# Altium schematic sheet FTDI.SchDoc(FTDI)
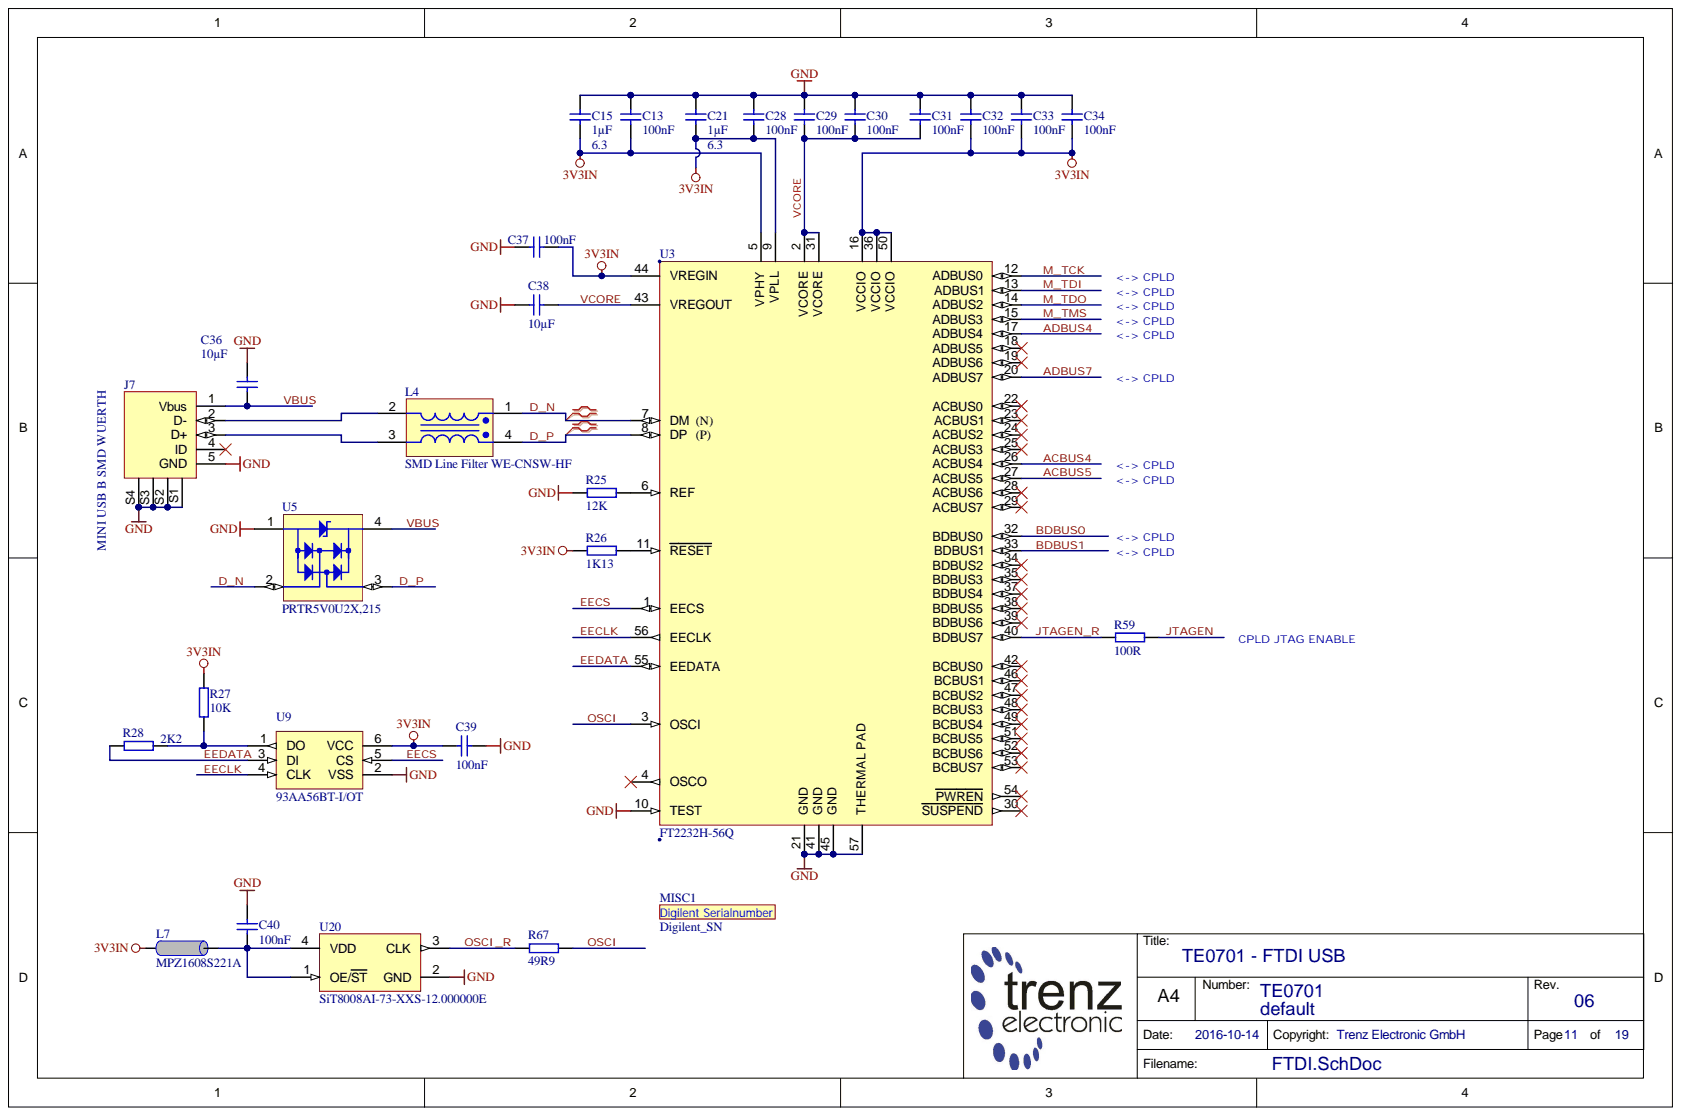
[diagram p0: FTDI.SchDoc]

## Parts
C13  100nF  [CAPC1005X55N]
C15  1µF  Voltage: 6.3  [CAPC1005X55N]
C21  1µF  Voltage: 6.3  [CAPC1005X55N]
C28  100nF  [CAPC1005X55N]
C29  100nF  [CAPC1005X55N]
C30  100nF  [CAPC1005X55N]
C31  100nF  [CAPC1005X55N]
C32  100nF  [CAPC1005X55N]
C33  100nF  [CAPC1005X55N]
C34  100nF  [CAPC1005X55N]
C36  10µF  [CAPC2012X135N]
C37  100nF  [CAPC1005X55N]
C38  10µF  [CAPC2012X135N]
C39  100nF  [CAPC1005X55N]
C40  100nF  [CAPC1005X55N]
J7  MINI USB B SMD WUERTH  [MINI USB 5S B TYPE]
L4  SMD Line Filter WE-CNSW-HF  [WE-CNSW-HF]
L7  MPZ1608S221A  [INDC1608X95N]
MISC1  Digilent_SN  (mechanical)
R25  12K  [RESC1005X40N]
R26  1K13  [RESC1005X40N]
R27  10K  [RESC1005X40N]
R28  2K2  [RESC1005X40N]
R59  100R  [RESC1005X40N]
R67  49R9  [RESC1005X40N]
U3  FT2232H-56Q  [QFN50P800X800-57T610X610N]
U5  PRTR5V0U2X,215  [SOT190P229X114-4N]
U9  93AA56BT-I/OT  [SOT95P280X145-6N]
U20  SiT8008AI-73-XXS-12.000000E  Database Table Name: CLK  [2016]

## Nets
3V3IN: C13.2 C15.2 C21.2 C28.2 C32.2 C33.2 C34.2 C37.2 C39.1 L7.1 R26.1 R27.1 U3.5(VPHY) U3.9(VPLL) U3.16(VCCIO) U3.36(VCCIO) U3.44(VREGIN) U3.50(VCCIO) U9.6(VCC)
GND: C13.1 C15.1 C21.1 C28.1 C29.1 C30.1 C31.1 C32.1 C33.1 C34.1 C36.1 C37.1 C38.1 C39.2 C40.2 J7.5(GND) J7.S1 J7.S2 J7.S3 J7.S4 R25.1 U3.10(TEST) U3.21(GND) U3.41(GND) U3.45(GND) U3.57(THERMAL_PAD) U5.1 U9.2(VSS) U20.2(GND)
ACBUS4: U3.26(ACBUS4)
ACBUS5: U3.27(ACBUS5)
ADBUS4: U3.17(ADBUS4)
ADBUS7: U3.20(ADBUS7)
BDBUS0: U3.32(BDBUS0)
BDBUS1: U3.33(BDBUS1)
D_N: L4.1 U3.7(DM) U5.2
D_P: L4.4 U3.8(DP) U5.3
EECLK: U3.56(EECLK) U9.4(CLK)
EECS: U3.1(EECS) U9.5(CS)
EEDATA: R28.1 U3.55(EEDATA) U9.3(DI)
JTAGEN: R59.2
JTAGEN_R: R59.1 U3.40(BDBUS7)
M_TCK: U3.12(ADBUS0)
M_TDI: U3.13(ADBUS1)
M_TDO: U3.14(ADBUS2)
M_TMS: U3.15(ADBUS3)
OSCI: R67.2 U3.3(OSCI)
OSCI_R: R67.1 U20.3(CLK)
VBUS: C36.2 J7.1(Vbus) U5.4
VCORE: C29.2 C30.2 C31.2 C38.2 U3.2(VCORE) U3.31(VCORE) U3.43(VREGOUT)
NetC40_1: C40.1 L7.2 U20.1(OE/ST) U20.4(VDD)
NetJ7_2: J7.2(D-) L4.2
NetJ7_3: J7.3(D+) L4.3
NetR25_2: R25.2 U3.6(REF)
NetR26_2: R26.2 U3.11(RESET)
NetR27_2: R27.2 R28.2 U9.1(DO)

Not connected: J7 4(ID); U3 4(OSCO) 18(ADBUS5) 19(ADBUS6) 22(ACBUS0) 23(ACBUS1) 24(ACBUS2) 25(ACBUS3) 28(ACBUS6) 29(ACBUS7) 30(SUSPEND) 34(BDBUS2) 35(BDBUS3) 37(BDBUS4) 38(BDBUS5) 39(BDBUS6) 42(BCBUS0) 46(BCBUS1) 47(BCBUS2) 48(BCBUS3) 49(BCBUS4) 51(BCBUS5) 52(BCBUS6) 53(BCBUS7) 54(PWREN)

## Library data of the parts
C13 C28-C34 C37 C39 C40 = 22472: KON 100nF 25V X5R SMD; Database Table Name: C_1005_0402; Mixed: SMT; Preferred: Yes; Value: 100n; AN: 22472; Case: 1005 (0402); Component Kind: Standard; Library Name: TE.DbLib; Technology: X7R; Voltage: 25V
C15 C21 = 23453: KON 1µF 6.3V X5R SMD 10%; Database Table Name: C_1005_0402; Mixed: SMT; Preferred: Yes; Value: 1u; AN: 23453; Case: 1005 (0402); Component Kind: Standard; Library Name: TE.DbLib; Technology: X5R; Tolerance: 10%
C36 C38 = 24380: KON 10µF 16V X7R SMD 10%; Database Table Name: C_2012_0805; Mixed: SMT; Preferred: Yes; Value: 10u; AN: 24380; Case: 2012 (0805); Component Kind: Standard; Library Name: TE.DbLib; Technology: X7R; Tolerance: 10%; Voltage: 16V
J7 = 24295: STE USB MINI B LP Buchse SMD; Database Table Name: CON_IO; H-ART: 65100516121; Mixed: SMT; Trenz-Stock: Yes; AN: 24295; Component Kind: Standard; Library Name: TE.DbLib; HelpURL: B:\AD\adlib\datasheet\65100516121
L4 = 24514: Stromkompensierter SMD Line Filter HF 320mA 0,24Ohm 50V; Database Table Name: L_SMD; H-ART: 744233670; Mixed: SMT; Preferred: Yes; AN: 24514; Component Kind: Standard; Library Name: TE.DbLib; Technology: 0.24Ohm; Trenz-Stock: Yes; Voltage: 320mA; HelpURL: B:\AD\adlib\datasheet\WE-CNSW HF
L7 = 22718: Ferritdurchführung MPZ1608S221A; Database Table Name: L_1608_0603; Mixed: SMT; Preferred: Yes; Value: 220 OHm @ 100MHz; AN: 22718; Case: 1608 (0603); Component Kind: Standard; H-ART: MPZ1608S221A; Library Name: TE.DbLib; Trenz-Stock: Yes; HelpURL: B:\AD\adlib\datasheet\MPZ1608
MISC1 = 27065: Digilent Serialnumber; Database Table Name: PCB; AN: 27065; Library Name: TE.DbLib
R25 = 22598: WID SMD 12K 1% 0,063W; Database Table Name: R_1005_0402; Mixed: SMT; Preferred: Yes; AN: 22598; Case: 1005 (0402); Component Kind: Standard; Library Name: TE.DbLib; Technology: TK100; Tolerance: 1%; Value: 12K
R26 = 23160: WID SMD 1K13 1% 0,063W; Database Table Name: R_1005_0402; Mixed: SMT; Preferred: Yes; Value: 1.13K; AN: 23160; Case: 1005 (0402); Component Kind: Standard; Library Name: TE.DbLib; Technology: TK100; Tolerance: 1%
R27 = 22750: WID SMD 10K 1% 0,063W; Database Table Name: R_1005_0402; Mixed: SMT; Preferred: Yes; AN: 22750; Case: 1005 (0402); Component Kind: Standard; Library Name: TE.DbLib; Technology: TK100; Tolerance: 1%; Value: 10K
R28 = 22748: WID SMD 2K2 1% 0,063W; Database Table Name: R_1005_0402; Mixed: SMT; Preferred: Yes; Value: 2.2K; AN: 22748; Case: 1005 (0402); Component Kind: Standard; Library Name: TE.DbLib; Technology: TK100; Tolerance: 1%
R59 = 22507: WID SMD 100R 1% 0,063W; Database Table Name: R_1005_0402; Mixed: SMT; Preferred: Yes; Value: 100; AN: 22507; Case: 1005 (0402); Component Kind: Standard; Library Name: TE.DbLib; Technology: TK100; Tolerance: 1%
R67 = 23135: WID SMD 49R9 1% 0,063W; Database Table Name: R_1005_0402; Mixed: SMT; Preferred: Yes; Value: 49.9; AN: 23135; Case: 1005 (0402); Component Kind: Standard; Library Name: TE.DbLib; Technology: TK100; Tolerance: 1%
U3 = 27277: Database Table Name: IF; Mixed: SMT; Preferred: Yes; AN: 27277; Case: VQFN56; Component Kind: Standard; Description: FT2232H-56Q; H-ART: FT2232H-56Q; Library Name: TE.DbLib; Trenz-Stock: Yes; HelpURL: B:\AD\adlib\datasheet\FT2232H
U5 = 24840: Database Table Name: DIODE; Mixed: SMT; Preferred: Yes; AN: 24840; Case: SOT143; Component Kind: Standard; Description: PRTR5V0U2X,215; H-ART: PRTR5V0U2X,215; Library Name: TE.DbLib; HelpURL: B:\AD\adlib\datasheet\CD143A-SR05
U9 = 24730: Database Table Name: EEPROM; Mixed: SMT; Preferred: Yes; AN: 24730; Case: SOT23-6; Component Kind: Standard; Description: 93AA56BT-I/OT; H-ART: 93AA56BT-I/OT; Library Name: TE.DbLib; Trenz-Stock: Yes; HelpURL: B:\AD\adlib\datasheet\93AA56A
U20 = 25325: SiT8008AI-73-XXS-12.000000E MEMS Oszillator 12MHz; Mixed: SMT; Preferred: Yes; Value: 12M; AN: 25325; Case: 2.0  x 1.6mm; Component Kind: Standard; H-ART: SiT8008AI-73-XXS-12.000000E; Library Name: TE.DbLib; Trenz-Stock: Yes; HelpURL: B:\AD\adlib\datasheet\SiT8008
